# Altium schematic sheet ATSAM3X8E Main Controller.SchDoc("ATSAM3X8E Main Controller")
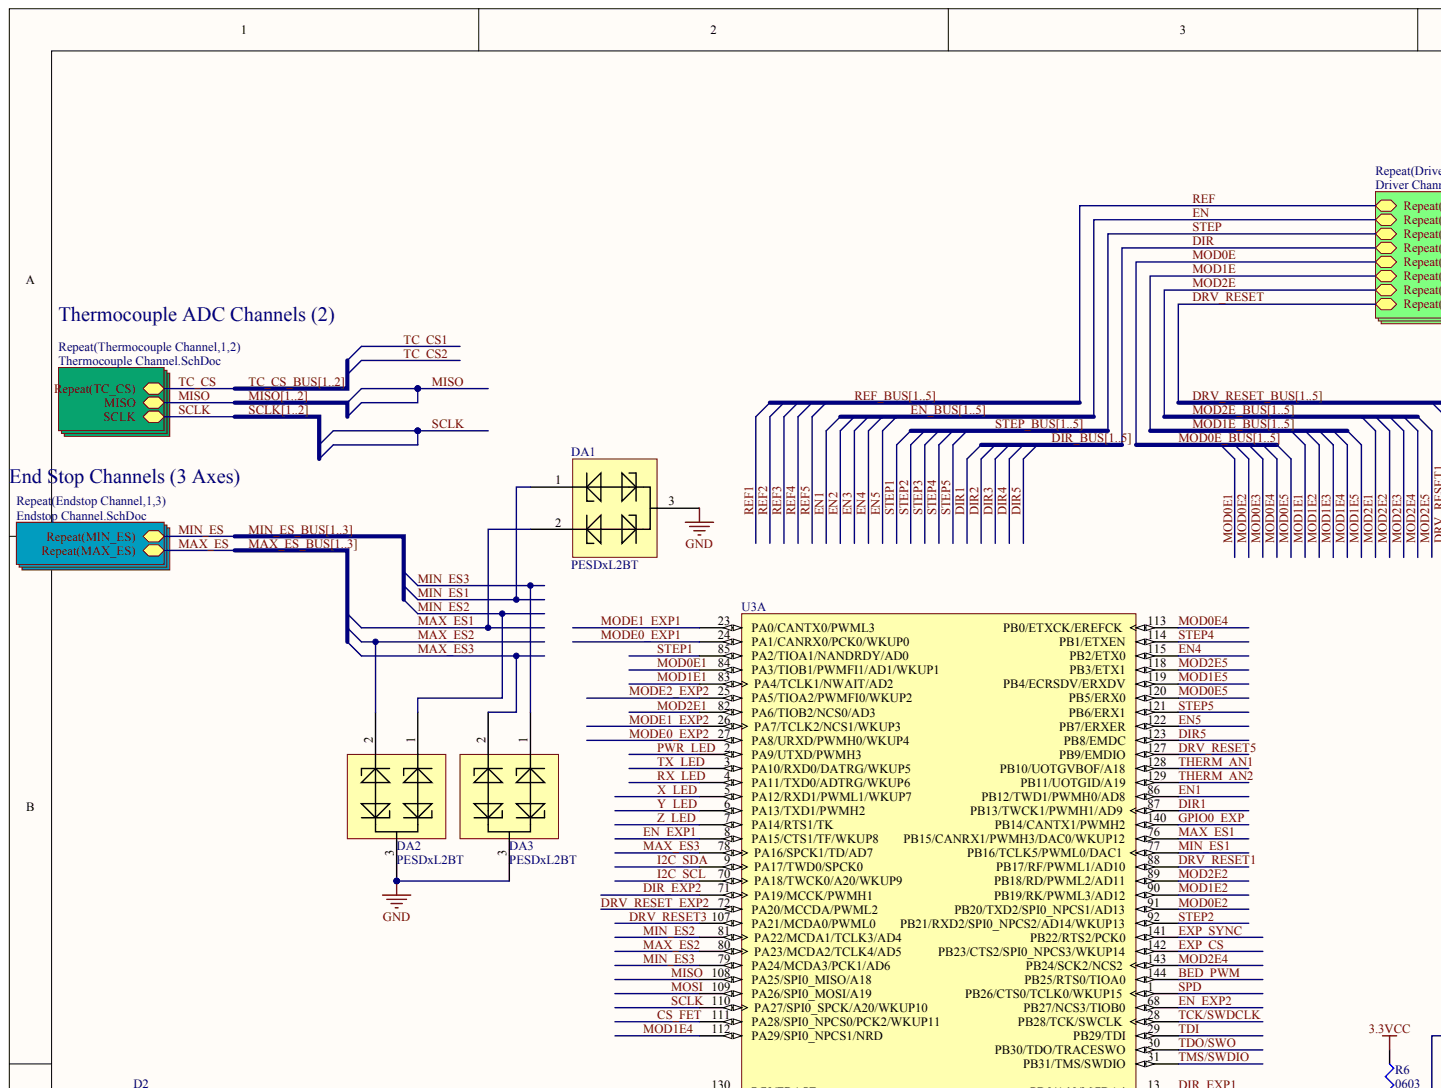
[diagram p0: ATSAM3X8E Main Controller.SchDoc, top left]
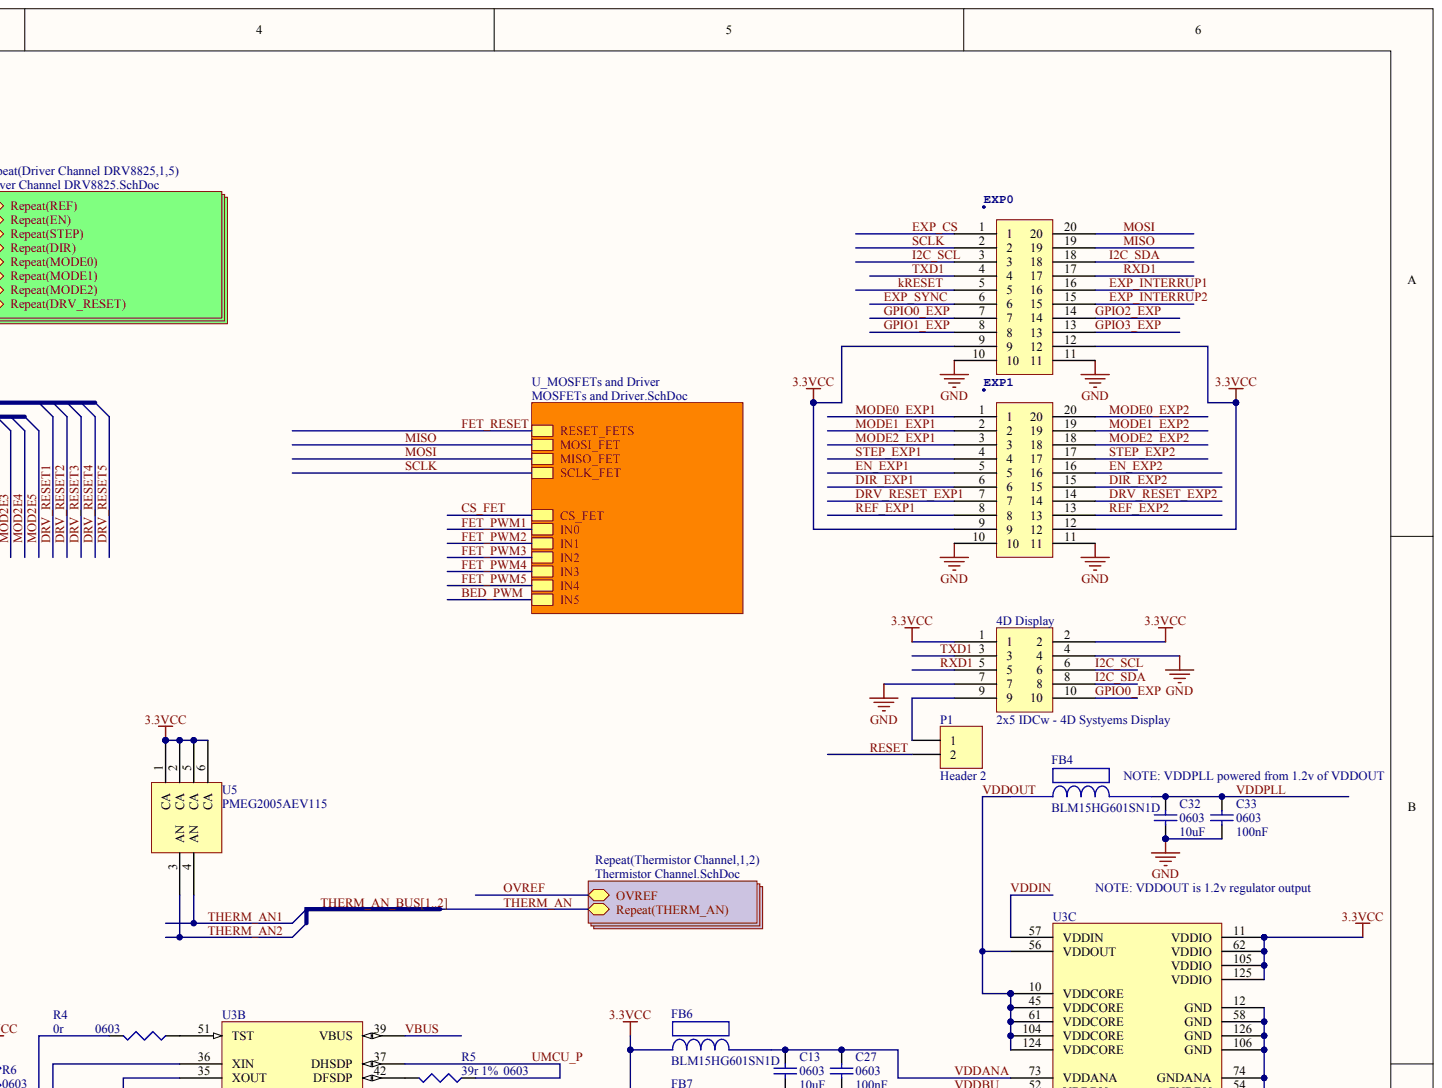
[diagram p1: ATSAM3X8E Main Controller.SchDoc, top right]
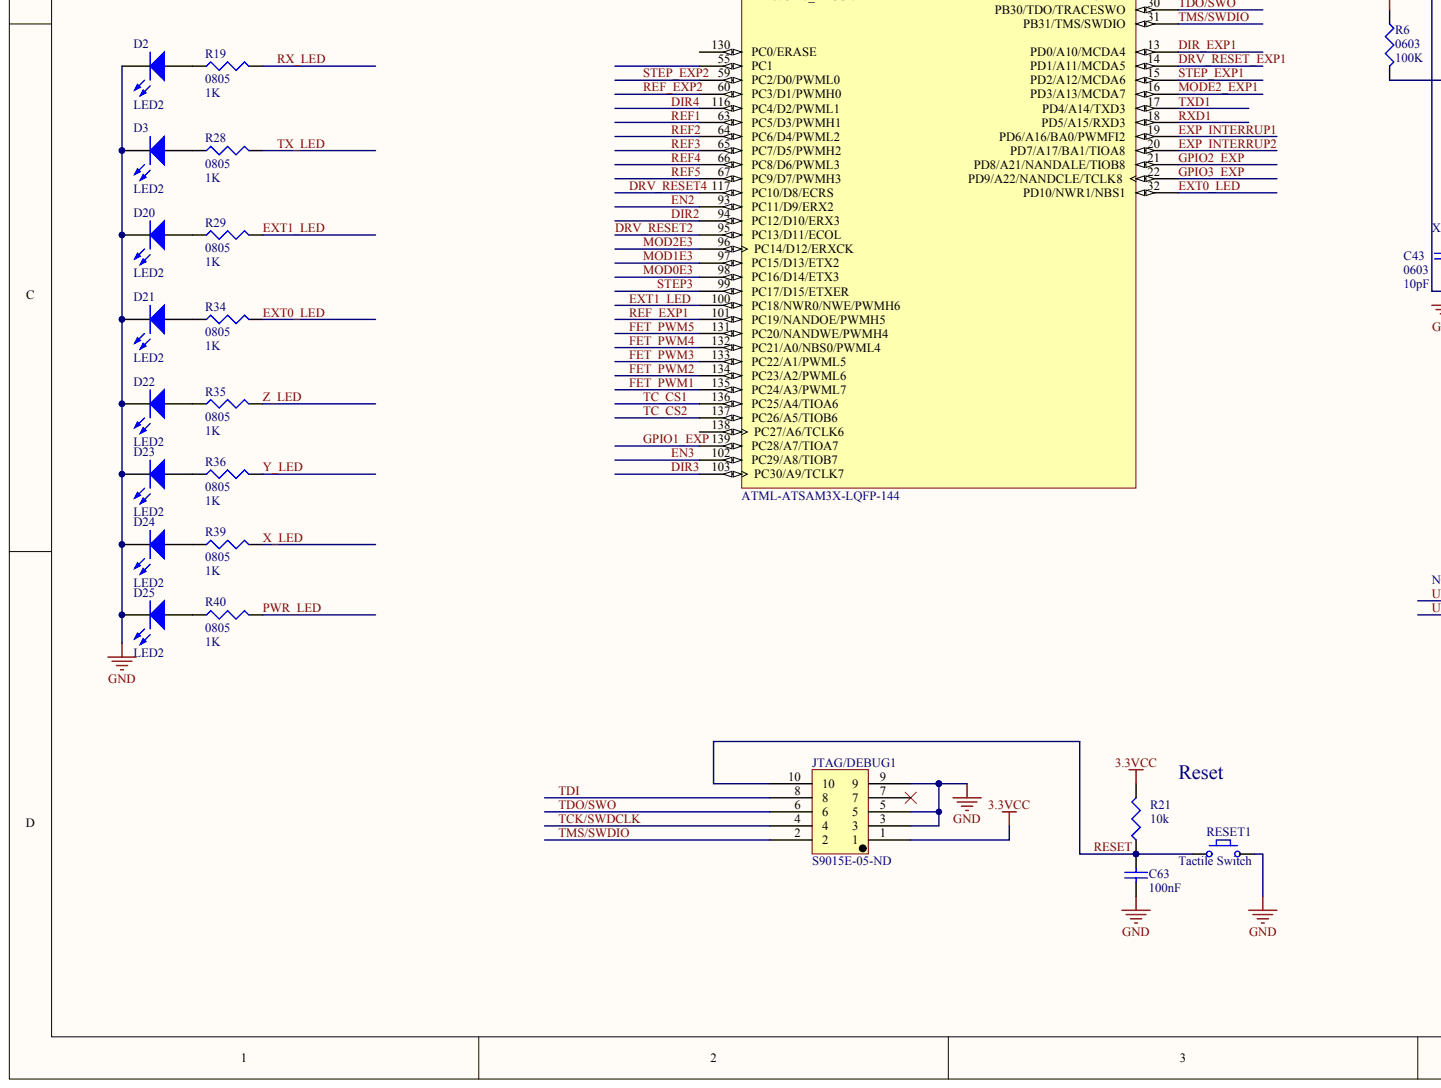
[diagram p2: ATSAM3X8E Main Controller.SchDoc, bottom left]
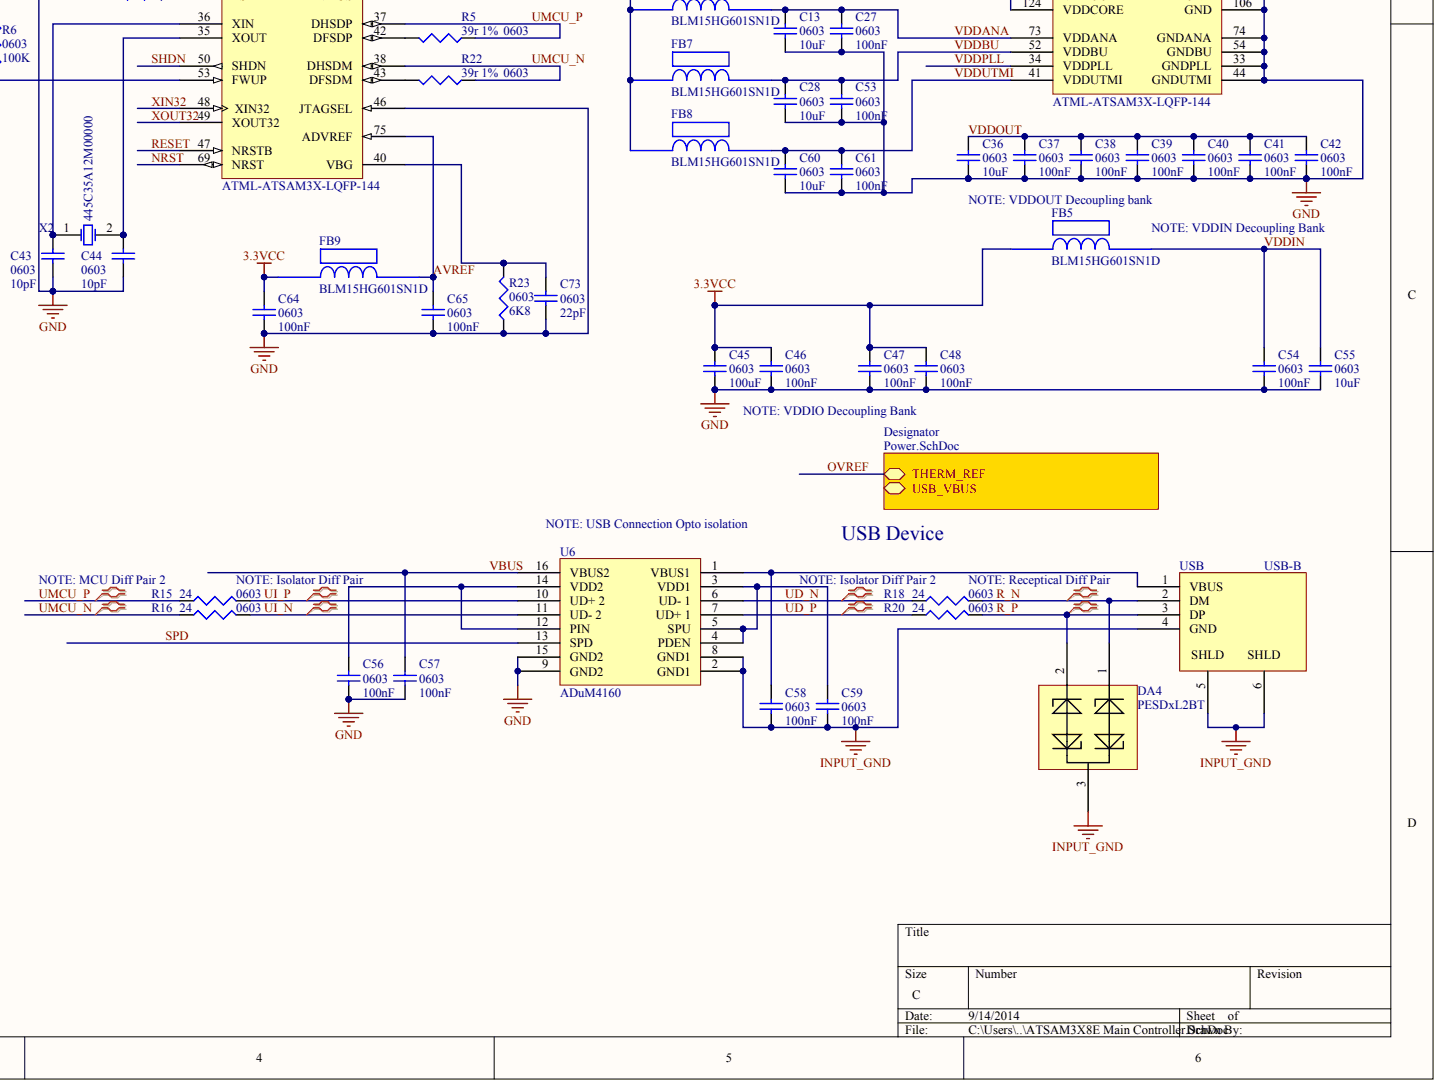
[diagram p3: ATSAM3X8E Main Controller.SchDoc, bottom right]

## Parts
4D_Display  2x5 IDCw - 4D Systyems Display
C13  0603  value 10uF
C27  0603  value 100nF
C28  0603  value 10uF
C32  0603  value 10uF
C33  0603  value 100nF
C36  0603  value 10uF
C37  0603  value 100nF
C38  0603  value 100nF
C39  0603  value 100nF
C40  0603  value 100nF
C41  0603  value 100nF
C42  0603  value 100nF
C43  0603  value 10pF
C44  0603  value 10pF
C45  0603  value 100uF
C46  0603  value 100nF
C47  0603  value 100nF
C48  0603  value 100nF
C53  0603  value 100nF
C54  0603  value 100nF
C55  0603  value 10uF
C56  0603  value 100nF
C57  0603  value 100nF
C58  0603  value 100nF
C59  0603  value 100nF
C60  0603  value 10uF
C61  0603  value 100nF
C63  value 100nF  (hidden comment: Cap Semi)
C64  0603  value 100nF
C65  0603  value 100nF
C73  0603  value 22pF
D2  LED2
D3  LED2
D20  LED2
D21  LED2
D22  LED2
D23  LED2
D24  LED2
D25  LED2
DA1  PESDxL2BT
DA2  PESDxL2BT
DA3  PESDxL2BT
DA4  PESDxL2BT
EXP0  10X2-0.1X0.05  (value not drawn)
EXP1  10X2-0.1X0.05  (value not drawn)
FB4  BLM15HG601SN1D
FB5  BLM15HG601SN1D
FB6  BLM15HG601SN1D
FB7  BLM15HG601SN1D
FB8  BLM15HG601SN1D
FB9  BLM15HG601SN1D
JTAG/DEBUG1  S9015E-05-ND
P1  Header 2
R4  0603  value 0r
R5  0603  value 39r 1%
R6  0603  value 100K
R15  0603  value 24
R16  0603  value 24
R18  0603  value 24
R19  0805  value 1K
R20  0603  value 24
R21  value 10k  (hidden comment: Res3)
R22  0603  value 39r 1%
R23  0603  value 6K8
R28  0805  value 1K
R29  0805  value 1K
R34  0805  value 1K
R35  0805  value 1K
R36  0805  value 1K
R39  0805  value 1K
R40  0805  value 1K
RESET1  Tactile Switch
U3  ATML-ATSAM3X-LQFP-144  (parts A,B,C)
U5  PMEG2005AEV115
U6  ADuM4160
USB  USB-B
X2  445C35A12M00000

## Nets
3.3VCC: 4D_Display.1 4D_Display.2 C45.2 C46.2 C47.2 C48.2 C64.2 EXP0.9 EXP0.12 EXP1.9 EXP1.12 FB5.1 FB6.1 FB7.1 FB8.1 FB9.1 JTAG/DEBUG1.1 R6.1 R21.2 U3.11(VDDIO) U3.62(VDDIO) U3.105(VDDIO) U3.125(VDDIO) U5.1(CA) U5.2(CA) U5.5(CA) U5.6(CA)
GND: 4D_Display.4 4D_Display.7 C13.1 C27.1 C28.1 C32.1 C33.1 C36.1 C37.1 C38.1 C39.1 C40.1 C41.1 C42.1 C43.1 C44.1 C45.1 C46.1 C47.1 C48.1 C53.1 C54.1 C55.1 C56.1 C57.1 C60.1 C61.1 C63.1 C64.1 C65.1 C73.1 D2.2 D3.2 D20.2 D21.2 D22.2 D23.2 D24.2 D25.2 DA1.3 DA2.3 DA3.3 EXP0.10 EXP0.11 EXP1.10 EXP1.11 JTAG/DEBUG1.3 JTAG/DEBUG1.5 JTAG/DEBUG1.9 R4.2 R23.1 RESET1.2 U3.12(GND) U3.33(GNDPLL) U3.44(GNDUTMI) U3.46(JTAGSEL) U3.54(GNDBU) U3.58(GND) U3.74(GNDANA) U3.106(GND) U3.126(GND) U6.9(GND2) U6.15(GND2)
INPUT_GND: C58.1 C59.1 DA4.3 U6.2(GND1) U6.8(GND1) USB.4(GND) USB.5 USB.6
AVREF: C65.2 FB9.2 U3.75(ADVREF)
BED_PWM: U3.144(PB25/RTS0/TIOA0)
CS_FET: U3.111(PA28/SPI0_NPCS0/PCK2/WKUP11)
DIR1: U3.87(PB13/TWCK1/PWMH1/AD9)
DIR2: U3.94(PC12/D10/ERX3)
DIR3: U3.103(PC30/A9/TCLK7)
DIR4: U3.116(PC4/D2/PWML1)
DIR5: U3.123(PB8/EMDC)
DIR_EXP1: EXP1.6 U3.13(PD0/A10/MCDA4)
DIR_EXP2: EXP1.15 U3.71(PA19/MCCK/PWMH1)
DRV_RESET1: U3.88(PB17/RF/PWML1/AD10)
DRV_RESET2: U3.95(PC13/D11/ECOL)
DRV_RESET3: U3.107(PA21/MCDA0/PWML0)
DRV_RESET4: U3.117(PC10/D8/ECRS)
DRV_RESET5: U3.127(PB9/EMDIO)
DRV_RESET_EXP1: EXP1.7 U3.14(PD1/A11/MCDA5)
DRV_RESET_EXP2: EXP1.14 U3.72(PA20/MCCDA/PWML2)
EN1: U3.86(PB12/TWD1/PWMH0/AD8)
EN2: U3.93(PC11/D9/ERX2)
EN3: U3.102(PC29/A8/TIOB7)
EN4: U3.115(PB2/ETX0)
EN5: U3.122(PB7/ERXER)
EN_EXP1: EXP1.5 U3.8(PA15/CTS1/TF/WKUP8)
EN_EXP2: EXP1.16 U3.68(PB27/NCS3/TIOB0)
EXP_CS: EXP0.1 U3.142(PB23/CTS2/SPI0_NPCS3/WKUP14)
EXP_INTERRUP1: EXP0.16 U3.19(PD6/A16/BA0/PWMFI2)
EXP_INTERRUP2: EXP0.15 U3.20(PD7/A17/BA1/TIOA8)
EXP_SYNC: EXP0.6 U3.141(PB22/RTS2/PCK0)
EXT0_LED: R34.2 U3.32(PD10/NWR1/NBS1)
EXT1_LED: R29.2 U3.100(PC18/NWR0/NWE/PWMH6)
FET_PWM1: U3.135(PC24/A3/PWML7)
FET_PWM2: U3.134(PC23/A2/PWML6)
FET_PWM3: U3.133(PC22/A1/PWML5)
FET_PWM4: U3.132(PC21/A0/NBS0/PWML4)
FET_PWM5: U3.131(PC20/NANDWE/PWMH4)
GPIO0_EXP: 4D_Display.10 EXP0.7 U3.140(PB14/CANTX1/PWMH2)
GPIO1_EXP: EXP0.8 U3.139(PC28/A7/TIOA7)
GPIO2_EXP: EXP0.14 U3.21(PD8/A21/NANDALE/TIOB8)
GPIO3_EXP: EXP0.13 U3.22(PD9/A22/NANDCLE/TCLK8)
I2C_SCL: 4D_Display.6 EXP0.3 U3.70(PA18/TWCK0/A20/WKUP9)
I2C_SDA: 4D_Display.8 EXP0.18 U3.9(PA17/TWD0/SPCK0)
KRESET: EXP0.5
MAX_ES1: DA1.2 U3.76(PB15/CANRX1/PWMH3/DAC0/WKUP12)
MAX_ES2: DA2.2 U3.80(PA23/MCDA2/TCLK4/AD5)
MAX_ES3: DA3.2 U3.78(PA16/SPCK1/TD/AD7)
MIN_ES1: DA1.1 U3.77(PB16/TCLK5/PWML0/DAC1)
MIN_ES2: DA2.1 U3.81(PA22/MCDA1/TCLK3/AD4)
MIN_ES3: DA3.1 U3.79(PA24/MCDA3/PCK1/AD6)
MISO: EXP0.19 U3.108(PA25/SPI0_MISO/A18)
MOD0E1: U3.84(PA3/TIOB1/PWMFI1/AD1/WKUP1)
MOD0E2: U3.91(PB20/TXD2/SPI0_NPCS1/AD13)
MOD0E3: U3.98(PC16/D14/ETX3)
MOD0E4: U3.113(PB0/ETXCK/EREFCK)
MOD0E5: U3.120(PB5/ERX0)
MOD1E1: U3.83(PA4/TCLK1/NWAIT/AD2)
MOD1E2: U3.90(PB19/RK/PWML3/AD12)
MOD1E3: U3.97(PC15/D13/ETX2)
MOD1E4: U3.112(PA29/SPI0_NPCS1/NRD)
MOD1E5: U3.119(PB4/ECRSDV/ERXDV)
MOD2E1: U3.82(PA6/TIOB2/NCS0/AD3)
MOD2E2: U3.89(PB18/RD/PWML2/AD11)
MOD2E3: U3.96(PC14/D12/ERXCK)
MOD2E4: U3.143(PB24/SCK2/NCS2)
MOD2E5: U3.118(PB3/ETX1)
MODE0_EXP1: EXP1.1 U3.24(PA1/CANRX0/PCK0/WKUP0)
MODE0_EXP2: EXP1.20 U3.27(PA8/URXD/PWMH0/WKUP4)
MODE1_EXP1: EXP1.2 U3.23(PA0/CANTX0/PWML3)
MODE1_EXP2: EXP1.19 U3.26(PA7/TCLK2/NCS1/WKUP3)
MODE2_EXP1: EXP1.3 U3.16(PD3/A13/MCDA7)
MODE2_EXP2: EXP1.18 U3.25(PA5/TIOA2/PWMFI0/WKUP2)
MOSI: EXP0.20 U3.109(PA26/SPI0_MOSI/A19)
NRST: U3.69(NRST)
PWR_LED: R40.2 U3.2(PA9/UTXD/PWMH3)
R_N: DA4.1 R18.2 USB.2(DM)
R_P: DA4.2 R20.2 USB.3(DP)
REF1: U3.63(PC5/D3/PWMH1)
REF2: U3.64(PC6/D4/PWML2)
REF3: U3.65(PC7/D5/PWMH2)
REF4: U3.66(PC8/D6/PWML3)
REF5: U3.67(PC9/D7/PWMH3)
REF_EXP1: EXP1.8 U3.101(PC19/NANDOE/PWMH5)
REF_EXP2: EXP1.13 U3.60(PC3/D1/PWMH0)
RESET: C63.2 JTAG/DEBUG1.10 P1.2 R21.1 RESET1.1 U3.47(NRSTB)
RX_LED: R19.2 U3.4(PA11/TXD0/ADTRG/WKUP6)
RXD1: 4D_Display.5 EXP0.17 U3.18(PD5/A15/RXD3)
SCLK: EXP0.2 U3.110(PA27/SPI0_SPCK/A20/WKUP10)
SHDN: U3.50(SHDN)
SPD: U3.1(PB26/CTS0/TCLK0/WKUP15) U6.13(SPD)
STEP1: U3.85(PA2/TIOA1/NANDRDY/AD0)
STEP2: U3.92(PB21/RXD2/SPI0_NPCS2/AD14/WKUP13)
STEP3: U3.99(PC17/D15/ETXER)
STEP4: U3.114(PB1/ETXEN)
STEP5: U3.121(PB6/ERX1)
STEP_EXP1: EXP1.4 U3.15(PD2/A12/MCDA6)
STEP_EXP2: EXP1.17 U3.59(PC2/D0/PWML0)
TC_CS1: U3.136(PC25/A4/TIOA6)
TC_CS2: U3.137(PC26/A5/TIOB6)
TCK/SWDCLK: JTAG/DEBUG1.4 U3.28(PB28/TCK/SWCLK)
TDI: JTAG/DEBUG1.8 U3.29(PB29/TDI)
TDO/SWO: JTAG/DEBUG1.6 U3.30(PB30/TDO/TRACESWO)
THERM_AN1: U3.128(PB10/UOTGVBOF/A18) U5.4(AN)
THERM_AN2: U3.129(PB11/UOTGID/A19) U5.3(AN)
TMS/SWDIO: JTAG/DEBUG1.2 U3.31(PB31/TMS/SWDIO)
TX_LED: R28.2 U3.3(PA10/RXD0/DATRG/WKUP5)
TXD1: 4D_Display.3 EXP0.4 U3.17(PD4/A14/TXD3)
UD_N: R18.1 U6.6(UD-_1)
UD_P: R20.1 U6.7(UD+_1)
UI_N: R16.2 U6.11(UD-_2)
UI_P: R15.2 U6.10(UD+_2)
UMCU_N: R16.1 R22.1 U3.38(DHSDM)
UMCU_P: R5.1 R15.1 U3.37(DHSDP)
VBUS: C57.2 U3.39(VBUS) U6.16(VBUS2)
VDDANA: C13.2 C27.2 FB6.2 U3.73(VDDANA)
VDDBU: C28.2 C53.2 FB7.2 U3.52(VDDBU)
VDDIN: C54.2 C55.2 FB5.2 U3.57(VDDIN)
VDDOUT: C36.2 C37.2 C38.2 C39.2 C40.2 C41.2 C42.2 FB4.1 U3.10(VDDCORE) U3.45(VDDCORE) U3.56(VDDOUT) U3.61(VDDCORE) U3.104(VDDCORE) U3.124(VDDCORE)
VDDPLL: C32.2 C33.2 FB4.2 U3.34(VDDPLL)
VDDUTMI: C60.2 C61.2 FB8.2 U3.41(VDDUTMI)
X_LED: R39.2 U3.5(PA12/RXD1/PWML1/WKUP7)
XIN32: U3.48(XIN32)
XOUT32: U3.49(XOUT32)
Y_LED: R36.2 U3.6(PA13/TXD1/PWMH2)
Z_LED: R35.2 U3.7(PA14/RTS1/TK)
Net4D Display_9: 4D_Display.9 P1.1
NetC43_2: C43.2 U3.36(XIN) X2.1
NetC44_2: C44.2 U3.35(XOUT) X2.2
NetC56_2: C56.2 U6.12(PIN) U6.14(VDD2)
NetC58_2: C58.2 U6.1(VBUS1) USB.1(VBUS)
NetC59_2: C59.2 U6.3(VDD1) U6.4(PDEN) U6.5(SPU)
NetC73_2: C73.2 R23.2 U3.40(VBG)
NetD2_1: D2.1 R19.1
NetD3_1: D3.1 R28.1
NetD20_1: D20.1 R29.1
NetD21_1: D21.1 R34.1
NetD22_1: D22.1 R35.1
NetD23_1: D23.1 R36.1
NetD24_1: D24.1 R39.1
NetD25_1: D25.1 R40.1
NetJTAG/DEBUG1_7: JTAG/DEBUG1.7
NetR4_1: R4.1 U3.51(TST)
NetR5_2: R5.2 U3.42(DFSDP)
NetR6_2: R6.2 U3.53(FWUP)
NetR22_2: R22.2 U3.43(DFSDM)
NetU3_55: U3.55(PC1)
NetU3_130: U3.130(PC0/ERASE)
NetU3_138: U3.138(PC27/A6/TCLK6)
DIR_BUS1:  [also labelled DIR_BUS]
DIR_BUS2:  [also labelled DIR_BUS]
DIR_BUS3:  [also labelled DIR_BUS]
DIR_BUS4:  [also labelled DIR_BUS]
DIR_BUS5:  [also labelled DIR_BUS]
DRV_RESET_BUS1:  [also labelled DRV_RESET_BUS]
DRV_RESET_BUS2:  [also labelled DRV_RESET_BUS]
DRV_RESET_BUS3:  [also labelled DRV_RESET_BUS]
DRV_RESET_BUS4:  [also labelled DRV_RESET_BUS]
DRV_RESET_BUS5:  [also labelled DRV_RESET_BUS]
EN_BUS1:  [also labelled EN_BUS]
EN_BUS2:  [also labelled EN_BUS]
EN_BUS3:  [also labelled EN_BUS]
EN_BUS4:  [also labelled EN_BUS]
EN_BUS5:  [also labelled EN_BUS]
FET_RESET:
MAX_ES_BUS1:  [also labelled MAX_ES_BUS]
MAX_ES_BUS2:  [also labelled MAX_ES_BUS]
MAX_ES_BUS3:  [also labelled MAX_ES_BUS]
MIN_ES_BUS1:  [also labelled MIN_ES_BUS]
MIN_ES_BUS2:  [also labelled MIN_ES_BUS]
MIN_ES_BUS3:  [also labelled MIN_ES_BUS]
MISO1:  [also labelled MISO]
MISO2:  [also labelled MISO]
MOD0E_BUS1:  [also labelled MOD0E_BUS]
MOD0E_BUS2:  [also labelled MOD0E_BUS]
MOD0E_BUS3:  [also labelled MOD0E_BUS]
MOD0E_BUS4:  [also labelled MOD0E_BUS]
MOD0E_BUS5:  [also labelled MOD0E_BUS]
MOD1E_BUS1:  [also labelled MOD1E_BUS]
MOD1E_BUS2:  [also labelled MOD1E_BUS]
MOD1E_BUS3:  [also labelled MOD1E_BUS]
MOD1E_BUS4:  [also labelled MOD1E_BUS]
MOD1E_BUS5:  [also labelled MOD1E_BUS]
MOD2E_BUS1:  [also labelled MOD2E_BUS]
MOD2E_BUS2:  [also labelled MOD2E_BUS]
MOD2E_BUS3:  [also labelled MOD2E_BUS]
MOD2E_BUS4:  [also labelled MOD2E_BUS]
MOD2E_BUS5:  [also labelled MOD2E_BUS]
OVREF:
REF_BUS1:  [also labelled REF_BUS]
REF_BUS2:  [also labelled REF_BUS]
REF_BUS3:  [also labelled REF_BUS]
REF_BUS4:  [also labelled REF_BUS]
REF_BUS5:  [also labelled REF_BUS]
SCLK1:  [also labelled SCLK]
SCLK2:  [also labelled SCLK]
STEP_BUS1:  [also labelled STEP_BUS]
STEP_BUS2:  [also labelled STEP_BUS]
STEP_BUS3:  [also labelled STEP_BUS]
STEP_BUS4:  [also labelled STEP_BUS]
STEP_BUS5:  [also labelled STEP_BUS]
TC_CS_BUS1:  [also labelled TC_CS_BUS]
TC_CS_BUS2:  [also labelled TC_CS_BUS]
THERM_AN_BUS1:  [also labelled THERM_AN_BUS]
THERM_AN_BUS2:  [also labelled THERM_AN_BUS]
USB_VBUS:

## Library data of the parts
EXP0 EXP1 = (no symbol name): LastRevisionNote: ImportComponents; Published: 23-Apr-2014; LastRevisionDate: 23-Apr-2014; Publisher: UltiMachine
4D_Display C13 C27 C28 C32 C33 C36-C48 C53-C61 C63-C65 C73 D2 D3 D20-D25 DA1-DA4 FB4-FB9 JTAG/DEBUG1 P1 R4-R6 R15 R16 R18-R23 R28 R29 R34-R36 R39 R40 RESET1 U3 U5 U6 USB X2 = (no symbol name)
  C13 C27 C28 C32 C33 C36-C48 C53-C61 C63-C65 C73: Published: 8-Jun-2000; LatestRevisionDate: 29-May-2009; LatestRevisionNote: IPC-7351 Footprint Added.; PackageReference: C1206; PackageDescription: Chip Capacitor
  D2 D3 D20-D25: Published: 8-Jun-2000; LatestRevisionDate: 17-Jul-2002; LatestRevisionNote: Re-released for DXP Platform.; PackageVersion: Feb-2002; PackageReference: 3.2X1.6X1.1; PackageDescription: SM LED; 2 Flat Leads
  P1: LatestRevisionDate: 17-Jul-2002; LatestRevisionNote: Re-released for DXP Platform.
  R4-R6 R15 R16 R18-R23 R28 R29 R34-R36 R39 R40: Published: 8-Jun-2000; LatestRevisionDate: 29-May-2009; LatestRevisionNote: IPC-7351 Footprint Added.; PackageReference: J1-0603; PackageDescription: Chip Resistor
  X2: Published: 8-Jun-2000; LatestRevisionDate: 17-Jul-2002; LatestRevisionNote: Re-released for DXP Platform.; PackageReference: R38; PackageDescription: Crystal, Thru-Hole; 2 Leads; Body 3.1 x 8.2 mm (Dia.xH)
  C13 C27 C28 C32 C33 C36-C48 C53-C61 C63-C65 C73 D2 D3 D20-D25 P1 R4-R6 R15 R16 R18-R23 R28 R29 R34-R36 R39 R40 X2: Publisher: Altium Limited
